# Altium schematic sheet slave.SchDoc(slave)
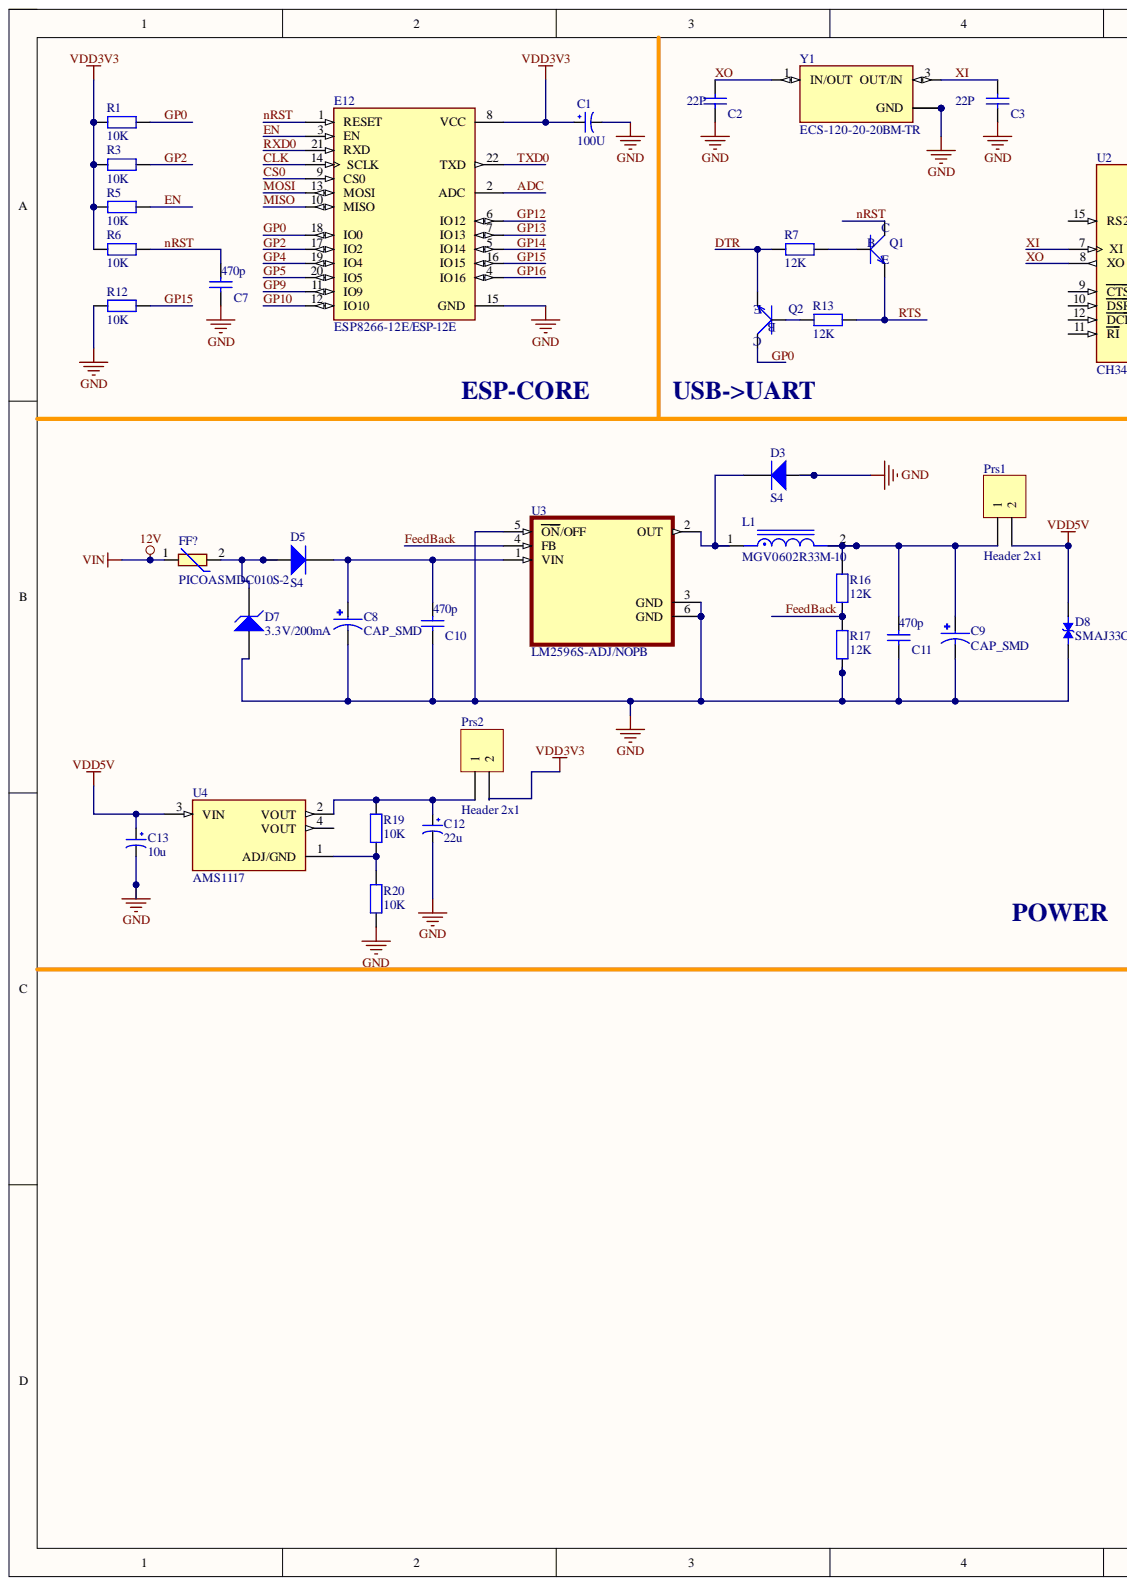
[diagram p0: slave.SchDoc, left]
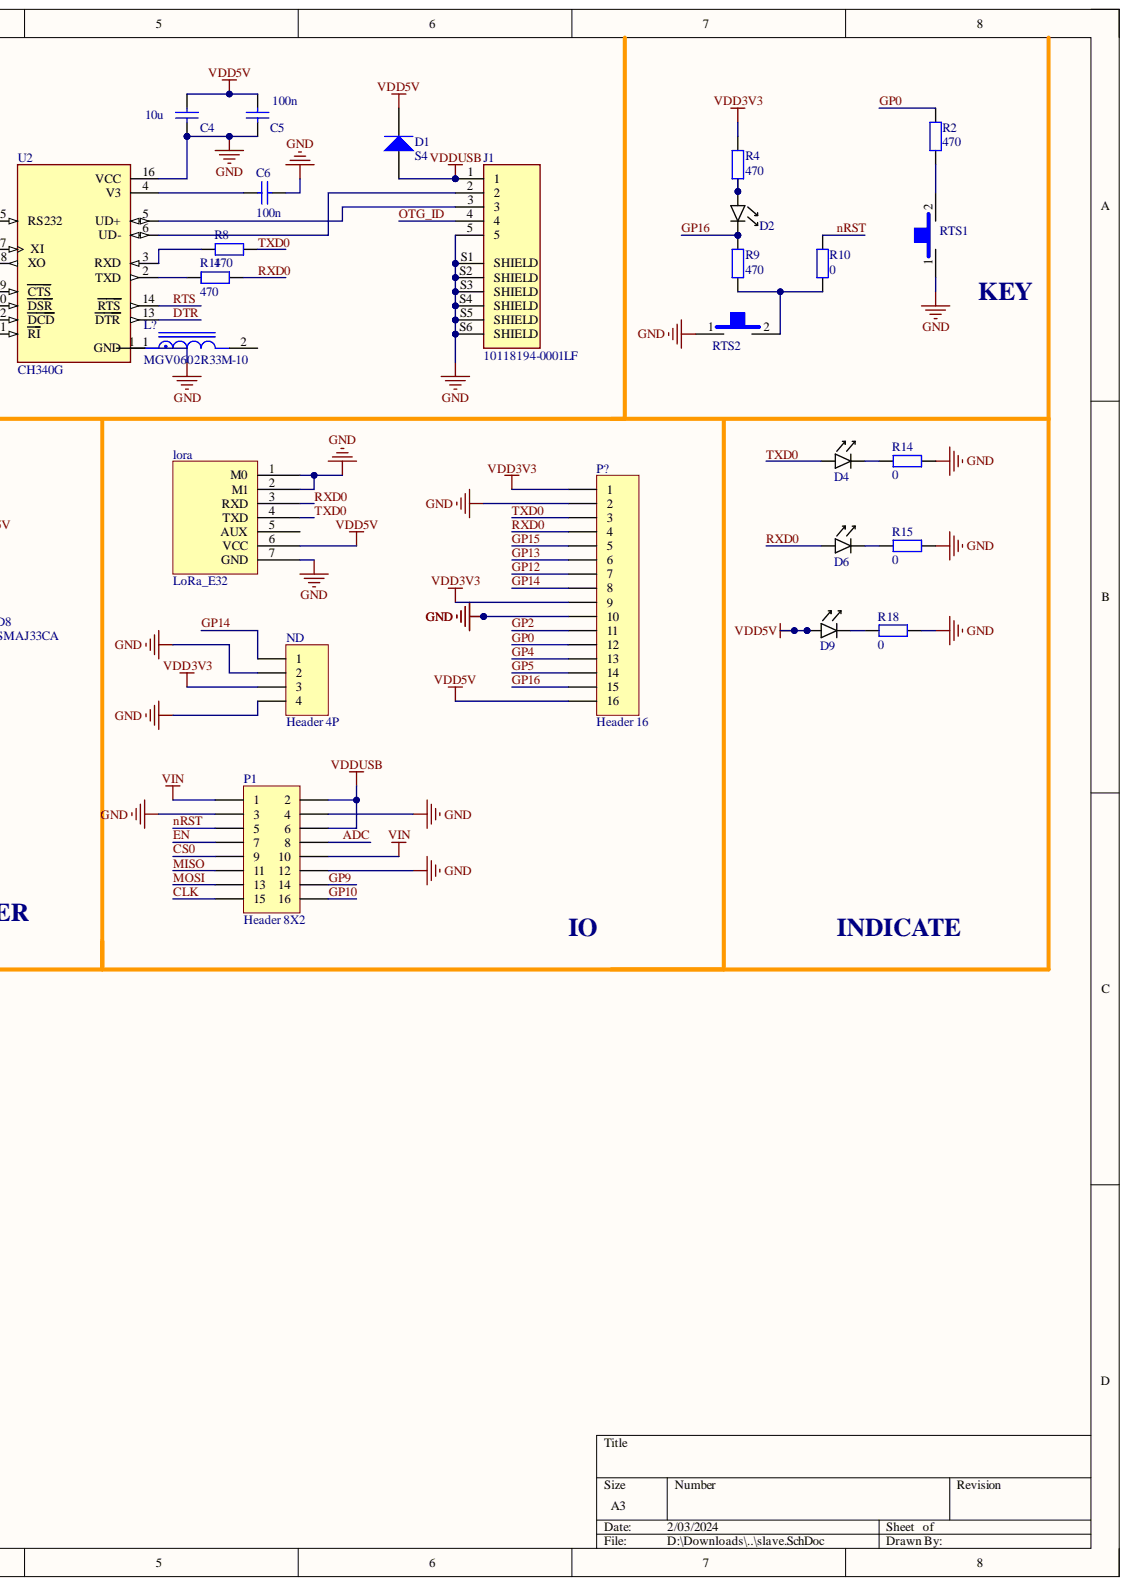
[diagram p1: slave.SchDoc, right]

## Parts
C1  100U  [tu tantalum smd7343]
C2  22P  [tu 0603]
C3  22P  [tu 0603]
C4  10u  [tu 0603]
C5  100n  [tu 0603]
C6  100n  [tu 0603]
C7  470p  [tu 0603]
C8  CAP_SMD  [CAP_EEEFK1H221GL]
C9  CAP_SMD  [CAP_EEEFK1H221GL]
C10  470p  [tu 0603]
C11  470p  [tu 0603]
C12  22u  [tu tantalum smd7343]
C13  10u  [tu tantalum smd7343]
D1  S4  [DIODE SOD-123]
D2  LED  [LED]  (value not drawn)
D3  S4  [DIODE SOD-123]
D4  LED  [LED]  (value not drawn)
D5  S4  [DIODE SOD-123]
D6  LED  [LED]  (value not drawn)
D7  3.3V/200mA  [DIODE_DO214AC_SMA]
D8  SMAJ33CA  [DIOM4325X250N]
D9  LED  [LED]  (value not drawn)
E12  ESP8266-12E/ESP-12E  [XCVR_ESP8266-12E/ESP-12E]
FF?  PICOASMDC010S-2  [FUSC2114X68N]  (value not drawn)
J1  10118194-0001LF  [AMPHENOL_10118194-0001LF]
L1  MGV0602R33M-10  [IND_MGV0602R33M-10]
L?  MGV0602R33M-10  [IND_MGV0602R33M-10]
lora  LoRa_E32  [LoRa_E32]
ND  Header 4P  [HDR1X4]
P1  Header 8X2  [HDR2X8]
P?  Header 16  [HDR1X16]
Prs1  Header 2x1  [HDR1X2]
Prs2  Header 2x1  [HDR1X2]
Q1  SMD-TRANSISTORS-NPN-25V-500MW(SOT-23)  [SOT-23]  (value not drawn)
Q2  SMD-TRANSISTORS-NPN-25V-500MW(SOT-23)  [SOT-23]  (value not drawn)
R1  10K  [tro smd 0603]
R2  470  [tro smd 0603]
R3  10K  [tro smd 0603]
R4  470  [tro smd 0603]
R5  10K  [tro smd 0603]
R6  10K  [tro smd 0603]
R7  12K  [tro smd 0603]
R8  470  [tro smd 0603]
R9  470  [tro smd 0603]
R10  0  [tro smd 0603]
R11  470  [tro smd 0603]
R12  10K  [tro smd 0603]
R13  12K  [tro smd 0603]
R14  0  [tro smd 0603]
R15  0  [tro smd 0603]
R16  12K  [tro smd 0603]
R17  12K  [tro smd 0603]
R18  0  [tro smd 0603]
R19  10K  [tro smd 0603]
R20  10K  [tro smd 0603]
RTS1  =value  [SW 2P SMD]
RTS2  =value  [SW 2P SMD]
U2  CH340G  [SOIC127P600X180-16N]
U3  LM2596S-ADJ/NOPB  [VREG_LM2596S-ADJ/NOPB]
U4  AMS1117  [SOT229P700X180-4N]
Y1  ECS-120-20-20BM-TR  [ECS_ECS-120-20-20BM-TR]

## Nets
12V: FF?.1 P1.1 P1.10
GND: C1.2 C2.2 C3.2 C4.2 C5.2 C6.1 C7.2 C8.2 C9.2 C10.2 C11.2 C12.2 C13.2 D3.1 D7.1 D8.1 E12.15(GND) J1.5 J1.S1(SHIELD) J1.S2(SHIELD) J1.S3(SHIELD) J1.S4(SHIELD) J1.S5(SHIELD) J1.S6(SHIELD) lora.1(M0) lora.2(M1) lora.7(GND) ND.2 ND.4 P1.3 P1.4 P1.12 P?.2 P?.10 R12.1 R14.2 R15.2 R17.2 R18.2 R20.1 RTS1.1 RTS2.1 U2.1(GND) U2.16(VCC) U3.3(GND) U3.5(ON/OFF) U3.6(GND) Y1.2(GND) Y1.4
VDD3V3: C1.1 E12.8(VCC) ND.3 P?.1 P?.9 Prs2.2 R1.1 R3.1 R4.1 R5.1 R6.1
VDD5V: C4.1 C5.1 C13.1 D1.2 D8.2 D9.A lora.6(VCC) P?.16 Prs1.2 U4.3(VIN)
VDDUSB: D1.1 J1.1 P1.2 P1.6
ADC: E12.2(ADC) P1.8
CLK: E12.14(SCLK) P1.15
CS0: E12.9(CS0) P1.9
DTR: Q2.2 R7.2 U2.13(DTR)
EN: E12.3(EN) P1.7 R5.2
FeedBack: R16.2 R17.1 U3.4(FB)
GP0: E12.18(IO0) P?.12 Q2.3 R1.2 R2.1
GP2: E12.17(IO2) P?.11 R3.2
GP4: E12.19(IO4) P?.13
GP5: E12.20(IO5) P?.14
GP9: E12.11(IO9) P1.14
GP10: E12.12(IO10) P1.16
GP12: E12.6(IO12) P?.7
GP13: E12.7(IO13) P?.6
GP14: E12.5(IO14) ND.1 P?.8
GP15: E12.16(IO15) P?.5 R12.2
GP16: D2.K E12.4(IO16) P?.15 R9.1
MISO: E12.10(MISO) P1.11
MOSI: E12.13(MOSI) P1.13
nRST: C7.1 E12.1(RESET) P1.5 Q1.3 R6.2 R10.1
OTG_ID: J1.4
RTS: Q1.2 R13.1 U2.14(RTS)
RXD0: D6.A E12.21(RXD) lora.3(RXD) P?.4 R11.1
TXD0: D4.A E12.22(TXD) lora.4(TXD) P?.3 R8.1
XI: C3.1 U2.7(XI) Y1.3(OUT/IN)
XO: C2.1 U2.8(XO) Y1.1(IN/OUT)
NetC6_2: C6.2 U2.4(V3)
NetC8_1: C8.1 C10.1 D5.2 U3.1(VIN)
NetC9_1: C9.1 C11.1 L1.2 Prs1.1 R16.1
NetC12_1: C12.1 Prs2.1 R19.2 U4.2(VOUT)
NetD2_A: D2.A R4.2
NetD3_2: D3.2 L1.1 U3.2(OUT)
NetD4_K: D4.K R14.1
NetD5_1: D5.1 D7.2 FF?.2
NetD6_K: D6.K R15.1
NetD9_K: D9.K R18.1
NetJ1_2: J1.2 U2.6(UD-)
NetJ1_3: J1.3 U2.5(UD+)
NetQ1_1: Q1.1 R7.1
NetQ2_1: Q2.1 R13.2
NetR2_2: R2.2 RTS1.2
NetR8_2: R8.2 U2.3(RXD)
NetR9_2: R9.2 R10.2 RTS2.2
NetR11_2: R11.2 U2.2(TXD)
NetR19_1: R19.1 R20.2 U4.1(ADJ/GND)

Not connected: L? 1 2; lora 5(AUX); U2 9(CTS) 10(DSR) 11(RI) 12(DCD) 15(RS232); U4 4(VOUT)

## Library data of the parts
C1 C12 C13 = Tu tantalum 220uF 10V SMD7343: Dán; Don_Vi: Con; Ma_Hang: MH007496; So_Chan: 2; Ten_Linh_Kien: Tụ tantalum 227A-220uF 10V SMD 7343; Chung_Loai: Dán; Component Kind: Standard; Library Name: DOAN1_Project.IntLib
C2-C7 C10 C11 = Tu 0603 101 50V 10%: Dán; Don_Vi: Con; Ma_Hang: MH004565; So_Chan: 2; Ten_Linh_Kien: Tụ 0603 101-0.1nF 10% 50V; Chung_Loai: Dán; Component Kind: Standard; Library Name: DOAN1_Project.IntLib
D1 D3 D5 = Diode S4: Dán; Don_Vi: Con; Ma_Hang: MH005519; Ten_Linh_Kien: Diode S4 SOD123 ( 1N5819 SOD123); Chung_Loai: Dán; Component Kind: Standard; Library Name: DOAN1_Project.IntLib
D7 = BZG05C3V3TR: DIODE ZENER 3.3V 1.25W 200mA  DO214AC; CompanyID: VT-00944; Solder: SMT; Component Kind: Standard; Library Name: DOAN1_Project.IntLib; MPN: BZG05C3V3TR; Value: 3.3V/200mA
D8 = SMAJ33CA: 69.7V Clamp 33A (8/20Âµs) Ipp Tvs Diode Surface Mount SMA (DO-214AC); MF: STMicroelectronics; MP: SMAJ33CA-TR; Availability: In Stock; Check_prices: https://www.snapeda.com/parts/SMAJ33CA-TR/STMicroelectronics/view-part/?ref=eda; Component Kind: Standard; Library Name: DOAN1_Project.IntLib; Package: DO-214 STMicroelectronics; Price: None; Purchase-URL: https://pricing.snapeda.com/search/part/SMAJ33CA-TR/?ref=eda; SnapEDA_Link: https://www.snapeda.com/parts/SMAJ33CA-TR/STMicroelectronics/view-part/?ref=snap
E12 = ESP8266-12E/ESP-12E: This WiFi module has a 32-bit MCU micro and clock speeds supporting 80 MHz or 160 MHz. Supports the RTOS and integrated Wi-Fi MAC/BB/RF/PA/LNA, and has an on-board antenna.; MF: AI-Thinker; Availability: Not in stock; Check_prices: https://www.snapeda.com/parts/ESP8266-12E/ESP-12E/AI-Thinker/view-part/?ref=eda; Component Kind: Standard; Library Name: DOAN1_Project.IntLib; MP: ESP8266-12E/ESP-12E; Package: None; Price: None; SnapEDA_Link: https://www.snapeda.com/parts/ESP8266-12E/ESP-12E/AI-Thinker/view-part/?ref=snap
J1 = 10118194-0001LF: Micro USB, Input Output Connectors, B TYPE RECEPTACLE with flange; MF: Amphenol ICC; Availability: In Stock; Check_prices: https://www.snapeda.com/parts/10118194-0001LF/Amphenol+FCI/view-part/?ref=eda; Component Kind: Standard; Library Name: DOAN1_Project.IntLib; MP: 10118194-0001LF; Package: None; Price: None; Purchase-URL: https://pricing.snapeda.com/search/part/10118194-0001LF/?ref=eda; SnapEDA_Link: https://www.snapeda.com/parts/10118194-0001LF/Amphenol+FCI/view-part/?ref=snap
L1 L? = MGV0602R33M-10: 330nH Ø³Ù„Ù Ù‚Ø§Ù„Ø¨ Ø¯Ø§Ø± Ù…Ø­Ø§ÙØ¸ 12A 7mOhm Max Nonstandard; MF: Laird; Availability: In Stock; Check_prices: https://www.snapeda.com/parts/MGV0602R33M-10/Laird/view-part/1656062/?ref=eda; Component Kind: Standard; Library Name: DOAN1_Project.IntLib; MP: MGV0602R33M-10; Package: Molded-2 Laird; Price: None; SnapEDA_Link: https://www.snapeda.com/parts/MGV0602R33M-10/Laird/view-part/1656062/?ref=snap
ND = Header 4x1: Header, 4 Pin Pitch 2.54mm; MPN: S1012EC-40-ND; CompanyID: VT-00125; Solder: WAVE; Component Kind: Standard; Library Name: DOAN1_Project.IntLib; Value: Header 4P
P1 = Header 8X2: Header, 8-Pin, Dual row; Component Kind: Standard; LatestRevisionDate: 17-Jul-2002; LatestRevisionNote: Re-released for DXP Platform.; Library Name: Miscellaneous Connectors.IntLib; Signal Integrity: Connector; Publisher: Altium Limited
P? = Header 16: Header, 16-Pin; Component Kind: Standard; LatestRevisionDate: 17-Jul-2002; LatestRevisionNote: Re-released for DXP Platform.; Library Name: Miscellaneous Connectors.IntLib; Signal Integrity: Connector; Publisher: Altium Limited
Prs1 Prs2 = Header 2x1: Header, 2-Pin, pitch 2.54mm; Solder: WAVE; Component Kind: Standard; Library Name: DOAN1_Project.IntLib; Signal Integrity: Connector
Q1 Q2 = SMD-TRANSISTORS-NPN-25V-500MW(SOT-23): Bipolar (BJT) Transistor NPN 25 V 500 mA 150MHz 300 mW Surface Mount SOT-23; MF: UMW; MP: S8050; Availability: In Stock; Check_prices: https://www.snapeda.com/parts/S8050/Umwelt+Sensor+Teknik/view-part/?ref=eda; Component Kind: Standard; Library Name: DOAN1_Project.IntLib; Package: None; Price: None; Purchase-URL: https://pricing.snapeda.com/search/part/S8050/?ref=eda; SnapEDA_Link: https://www.snapeda.com/parts/S8050/Umwelt+Sensor+Teknik/view-part/?ref=snap
R1-R20 = Tro 0603 1% 10k: Dán; Don_Vi: Con; Ma_Hang: MH006333; So_Chan: 2; Ten_Linh_Kien: Trở 0603 1% 10k; Chung_Loai: Dán; Component Kind: Standard; Library Name: DOAN1_Project.IntLib
RTS1 RTS2 = Button 2 SMD: SMD Mini Push Button Switch 2 Pin 3x6x2.7mm; MPN: SMD Mini Push Button 2 SMD; Component Kind: Standard; Library Name: DOAN1_Project.IntLib; Link: https://ram-e-shop.com/product/press2pin-smd/
U2 = CH340G: USB Interface Integrated Circuit; MF: WCH; Availability: Not in stock; Check_prices: https://www.snapeda.com/parts/Ch340G/WCH/view-part/?ref=eda; Component Kind: Standard; Library Name: DOAN1_Project.IntLib; MP: Ch340G; Package: None; Price: None; SnapEDA_Link: https://www.snapeda.com/parts/Ch340G/WCH/view-part/?ref=snap
U3 = LM2596S-ADJ/NOPB: SIMPLE SWITCHER&reg; 4.5V to 40V, 3A Low Component Count Step-Down Regulator; MF: Texas Instruments; Availability: In Stock; Check_prices: https://www.snapeda.com/parts/LM2596S-ADJ/NOPB/Texas+Instruments/view-part/?ref=eda; Component Kind: Standard; Library Name: DOAN1_Project.IntLib; MP: LM2596S-ADJ/NOPB; Package: TO-263-5 Texas Instruments; Price: None; Purchase-URL: https://pricing.snapeda.com/search/part/LM2596S-ADJ/NOPB/?ref=eda; SnapEDA_Link: https://www.snapeda.com/parts/LM2596S-ADJ/NOPB/Texas+Instruments/view-part/?ref=snap
U4 = AMS1117: 1A LOW DROPOUT VOLTAGE REGULATOR SOT-223; MF: Advanced Monolithic Systems; Availability: Not in stock; Check_prices: https://www.snapeda.com/parts/AMS1117/Advanced+Monolithic+Systems/view-part/?ref=eda; Component Kind: Standard; Library Name: DOAN1_Project.IntLib; MP: AMS1117; Package: SOT-223 Seeed Technology; Price: None; SnapEDA_Link: https://www.snapeda.com/parts/AMS1117/Advanced+Monolithic+Systems/view-part/?ref=snap
Y1 = ECS-120-20-20BM-TR: Crystal  4-SMD, No Lead; Availability: Unavailable; Component Kind: Standard; Library Name: DOAN1_Project.IntLib; MP: ECS-120-20-20BM-TR; Package: None; Price: None
C8 C9 = CAP_SMD: Component Kind: Standard; Library Name: DOAN1_Project.IntLib
D2 D4 D6 D9 = LED: Component Kind: Standard; Library Name: My Components Duc.IntLib
FF? = PICOASMDC010S-2: Availability: Unavailable; Component Kind: Standard; Library Name: DOAN1_Project.IntLib; MP: PICOASMDC010S-2; Package: None; Price: None
lora = LoRa_E32: Component Kind: Standard; Library Name: DOAN1_Project.IntLib
